# Altium schematic sheet tmc2226模块.SchDoc(tmc2226模块)
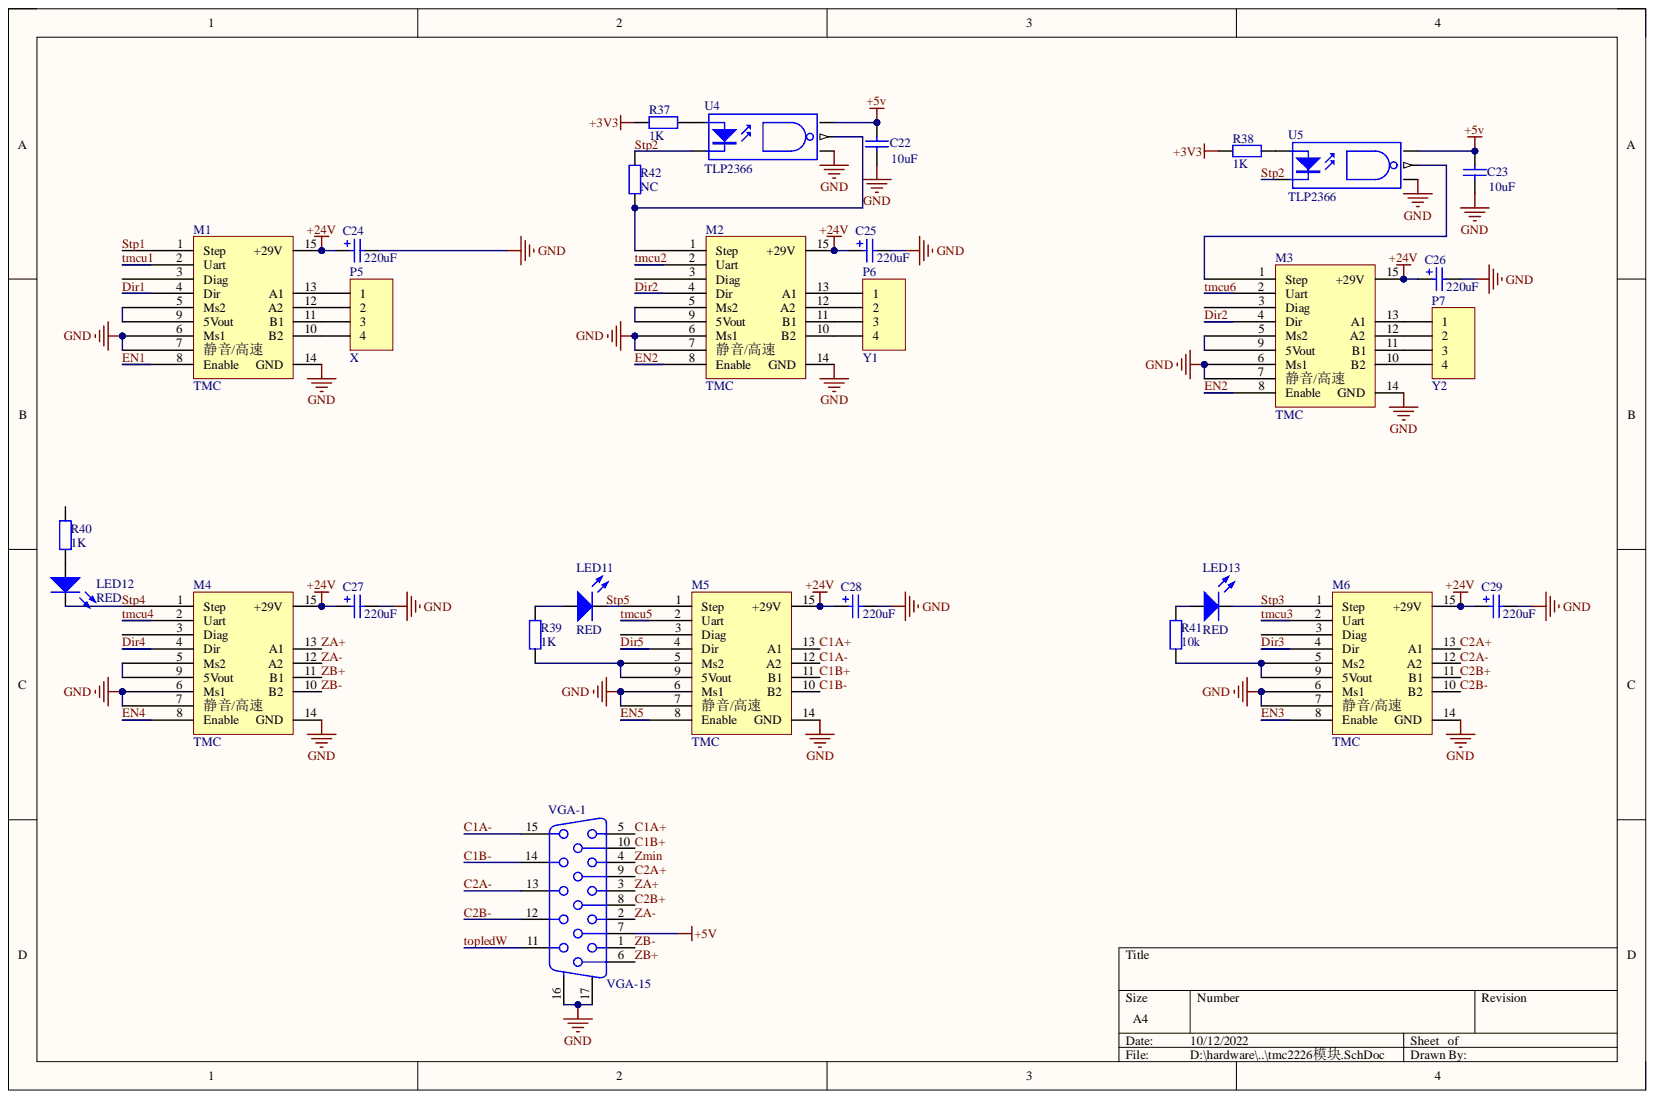
[diagram p0: tmc2226模块.SchDoc]

## Parts
C22  10uF  [0805c]
C23  10uF  [0805c]
C24  220uF  [CAP-SMD_BD8.0-L8.3-W8.3-FD]
C25  220uF  [CAP-SMD_BD8.0-L8.3-W8.3-FD]
C26  220uF  [CAP-SMD_BD8.0-L8.3-W8.3-FD]
C27  220uF  [CAP-SMD_BD8.0-L8.3-W8.3-FD]
C28  220uF  [CAP-SMD_BD8.0-L8.3-W8.3-FD]
C29  220uF  [CAP-SMD_BD8.0-L8.3-W8.3-FD]
LED11  RED  [0805d_red]
LED12  RED  [0805d_red]
LED13  RED  [0805d_red]
M1  TMC  [TMC2226]
M2  TMC  [TMC2226]
M3  TMC  [TMC2226]
M4  TMC  [TMC2226]
M5  TMC  [TMC2226]
M6  TMC  [TMC2226]
P5  X  [KF2EDG-2.54-4-H]
P6  Y1  [KF2EDG-2.54-4-H]
P7  Y2  [KF2EDG-2.54-4-H]
R37  1K  [0805r]
R38  1K  [0805r]
R39  1K  [0805r]
R40  1K  [0805r]
R41  10k  [0805r]
R42  NC  [0805r]
U4  TLP2366  [TLP2366]
U5  TLP2366  [TLP2366]
VGA-1  VGA-15  [DR15-VGA]

## Nets
+3V3: R37.2 R38.2
+5v: C22.2 C23.2 U4.6 U5.6 VGA-1.7
+24V: C24.1 C25.1 C26.1 C27.1 C28.1 C29.1 M1.15(+29V) M2.15(+29V) M3.15(+29V) M4.15(+29V) M5.15(+29V) M6.15(+29V)
GND: C22.1 C23.1 C24.2 C25.2 C26.2 C27.2 C28.2 C29.2 M1.6(Ms1) M1.7(静音) M1.14(GND) M2.6(Ms1) M2.7(静音) M2.14(GND) M3.6(Ms1) M3.7(静音) M3.14(GND) M4.6(Ms1) M4.7(静音) M4.14(GND) M5.6(Ms1) M5.7(静音) M5.14(GND) M6.6(Ms1) M6.7(静音) M6.14(GND) U4.4 U5.4 VGA-1.16 VGA-1.17
C1A+: M5.13(A1) VGA-1.5
C1A-: M5.12(A2) VGA-1.15
C1B+: M5.11(B1) VGA-1.10
C1B-: M5.10(B2) VGA-1.14
C2A+: M6.13(A1) VGA-1.9
C2A-: M6.12(A2) VGA-1.13
C2B+: M6.11(B1) VGA-1.8
C2B-: M6.10(B2) VGA-1.12
Dir1: M1.4(Dir)
Dir2: M2.4(Dir) M3.4(Dir)
Dir3: M6.4(Dir)
Dir4: M4.4(Dir)
Dir5: M5.4(Dir)
EN1: M1.8(Enable)
EN2: M2.8(Enable) M3.8(Enable)
EN3: M6.8(Enable)
EN4: M4.8(Enable)
EN5: M5.8(Enable)
Stp1: M1.1(Step)
Stp2: R42.1 U4.3 U5.3
Stp3: LED13.2 M6.1(Step)
Stp4: LED12.2 M4.1(Step)
Stp5: LED11.2 M5.1(Step)
tmcu1: M1.2(Uart)
tmcu2: M2.2(Uart)
tmcu3: M6.2(Uart)
tmcu4: M4.2(Uart)
tmcu5: M5.2(Uart)
tmcu6: M3.2(Uart)
topledW: VGA-1.11
ZA+: M4.13(A1) VGA-1.3
ZA-: M4.12(A2) VGA-1.2
ZB+: M4.11(B1) VGA-1.6
ZB-: M4.10(B2) VGA-1.1
Zmin: VGA-1.4
NetLED11_1: LED11.1 R39.2
NetLED12_1: LED12.1 R40.2
NetLED13_1: LED13.1 R41.2
NetM1_5: M1.5(Ms2) M1.9(5Vout)
NetM1_10: M1.10(B2) P5.4
NetM1_11: M1.11(B1) P5.3
NetM1_12: M1.12(A2) P5.2
NetM1_13: M1.13(A1) P5.1
NetM2_1: M2.1(Step) R42.2 U4.5
NetM2_5: M2.5(Ms2) M2.9(5Vout)
NetM2_10: M2.10(B2) P6.4
NetM2_11: M2.11(B1) P6.3
NetM2_12: M2.12(A2) P6.2
NetM2_13: M2.13(A1) P6.1
NetM3_1: M3.1(Step) U5.5
NetM3_5: M3.5(Ms2) M3.9(5Vout)
NetM3_10: M3.10(B2) P7.4
NetM3_11: M3.11(B1) P7.3
NetM3_12: M3.12(A2) P7.2
NetM3_13: M3.13(A1) P7.1
NetM4_5: M4.5(Ms2) M4.9(5Vout)
NetM5_5: M5.5(Ms2) M5.9(5Vout) R39.1
NetM6_5: M6.5(Ms2) M6.9(5Vout) R41.1
NetR37_1: R37.1 U4.1
NetR38_1: R38.1 U5.1

Not connected: M1 3(Diag); M2 3(Diag); M3 3(Diag); M4 3(Diag); M5 3(Diag); M6 3(Diag); R40 1

## Library data of the parts
C22 C23 = Cap: 无极性定值电容; Component Kind: Standard; LatestRevisionDate: 17-Jul-2002; LatestRevisionNote: Re-released for DXP Platform.; Library Name: 常用.SchLib; PackageDescription: Radial Cap, Thru-Hole; 2 Leads; 0.3 in Pin Spacing; PackageReference: RAD-0.3; Published: 8-Jun-2000; Signal Integrity: Cap; Simulation: CAP; Publisher: Altium Limited
C24-C29 = Cap Pol1: 有极性定值电容器; Component Kind: Standard; LatestRevisionDate: 17-Jul-2002; LatestRevisionNote: Re-released for DXP Platform.; Library Name: 常用.SchLib; PackageDescription: Polarized Capacitor (Radial); 2 Leads; PackageReference: RB7.6-15; Signal Integrity: Cap Pol; Simulation: CAP; Publisher: Altium Limited
LED11-LED13 = LED0: 普通LED; Component Kind: Standard; LatestRevisionDate: 08-Jul-2005; LatestRevisionNote: Mech Layer 1 removed.; Library Name: 常用.SchLib; PackageDescription: LED; 2 Leads; PackageReference: LED-0; Simulation: LED0; Value: RED; Publisher: Altium Limited
M1-M6 = TMC2226M: Component Kind: Standard; Library Name: 开发板核心板.SchLib
P5-P7 = Header 4: Header, 4-Pin; Component Kind: Standard; LatestRevisionDate: 17-Jul-2002; LatestRevisionNote: Re-released for DXP Platform.; Signal Integrity: Connector; Library Name: 接插头.SchLib; Publisher: Altium Limited
R37 R38 R42 = Res2: 定值电阻; Component Kind: Standard; LatestRevisionDate: 17-Jul-2002; LatestRevisionNote: Re-released for DXP Platform.; Library Name: 常用.SchLib; PackageDescription: Axial Device, Thru-Hole; 2 Leads; 0.4 in Pin Spacing; PackageReference: AXIAL-0.4; Published: 8-Jun-2000; Signal Integrity: Res; Simulation: RESISTOR; Publisher: Altium Limited
R39-R41 = Res2: 固定电阻器; Component Kind: Standard; LatestRevisionDate: 17-Jul-2002; LatestRevisionNote: Re-released for DXP Platform.; Library Name: 常用.SchLib; PackageDescription: Axial Device, Thru-Hole; 2 Leads; 0.4 in Pin Spacing; PackageReference: AXIAL-0.4; Published: 8-Jun-2000; Signal Integrity: Res; Simulation: RESISTOR; Publisher: Altium Limited
U4 U5 = TLP2366: 20M高速光耦; Component Kind: Standard; Library Name: 常用.SchLib
VGA-1 = VGA-15: Receptacle Assembly, 15-Pin, Sim Line Connector; Gender: Receptacle Header; PackageDocument: B; Positions: 15; Component Kind: Standard; DatasheetDocument: 24-Nov-1999; LatestRevisionDate: 17-Jul-2002; LatestRevisionNote: Re-released for DXP Platform.; Orientation: Right Angle; PackageReference: 788574; Published: 15-Jun-2001; Library Name: 接插头.SchLib; Publisher: Altium Limited
